# Altium schematic sheet power_do.SchDoc(power_do)
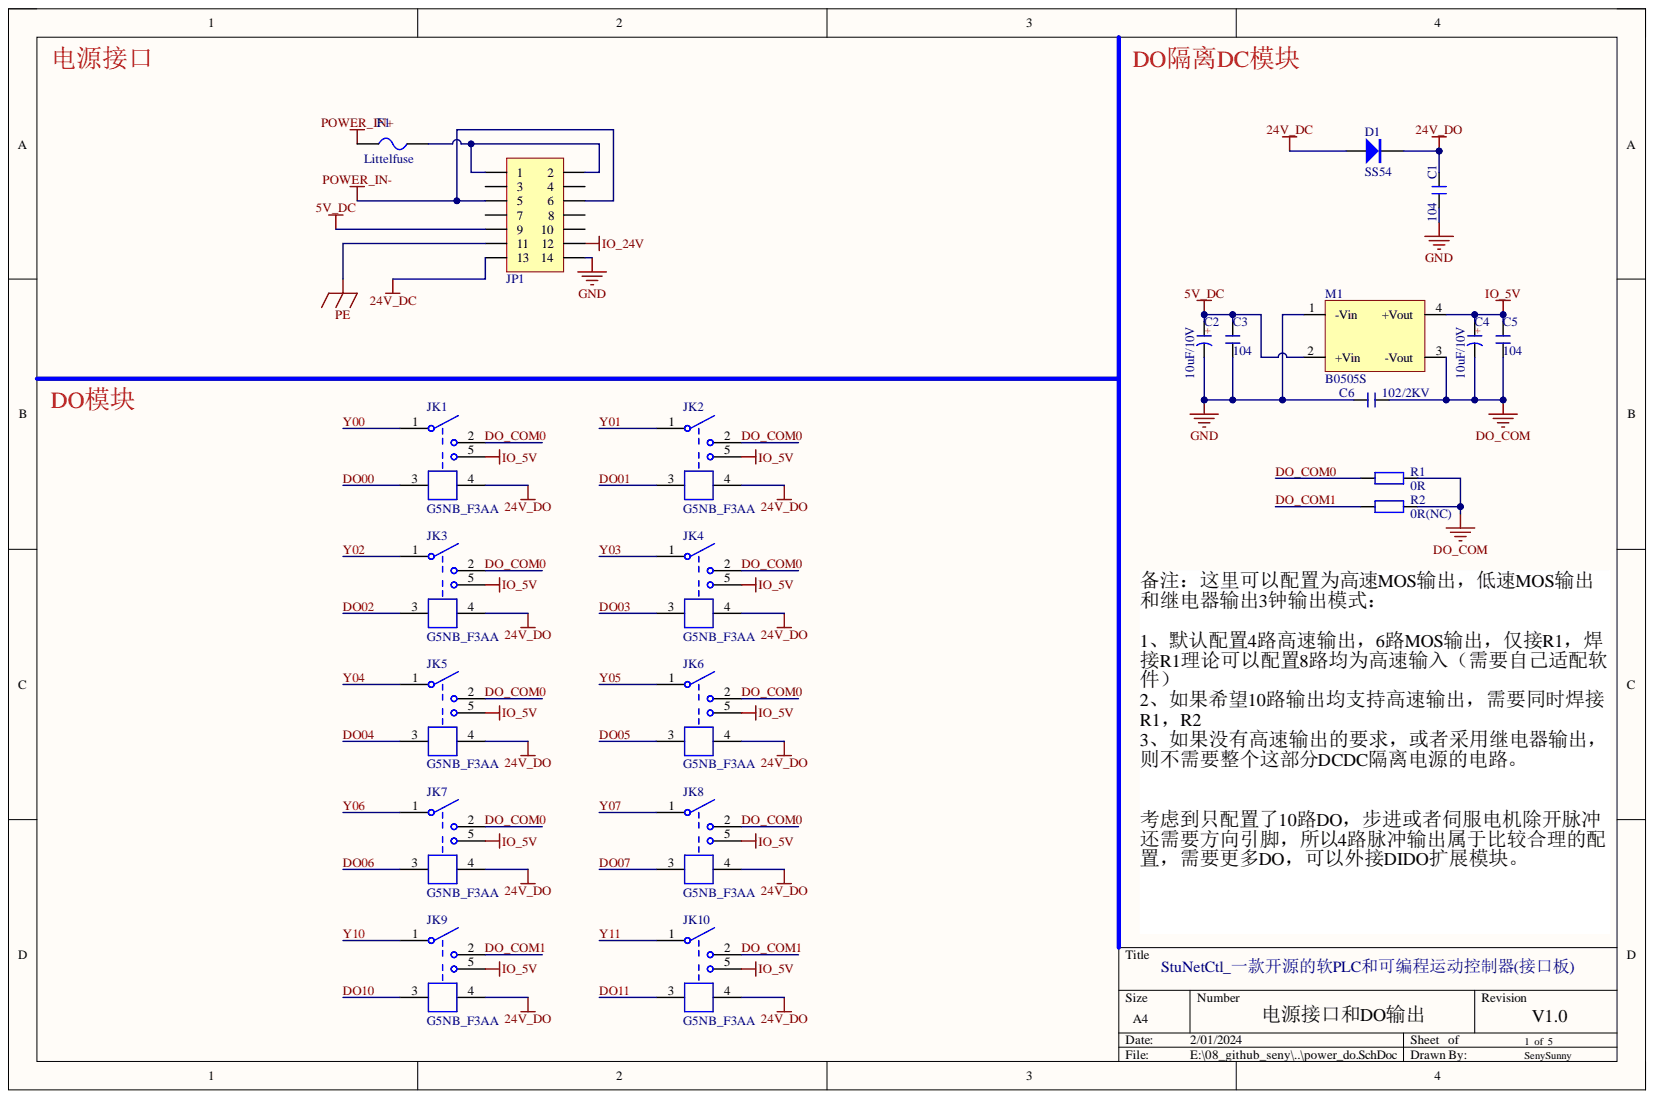
[diagram p0: power_do.SchDoc]

## Parts
C1  104  [C 0603]
C2  10uF/10V  [CD A(3216)]
C3  104  [C 0603]
C4  10uF/10V  [CD A(3216)]
C5  104  [C 0603]
C6  102/2KV  [C 1206]
D1  SS54  [SMA(DO214AC)]
F1  Littelfuse  [FUSE-SMD_L9.8-W5.0]
JK1  G5NB_F3AA  [G5NB_F3AA]
JK2  G5NB_F3AA  [G5NB_F3AA]
JK3  G5NB_F3AA  [G5NB_F3AA]
JK4  G5NB_F3AA  [G5NB_F3AA]
JK5  G5NB_F3AA  [G5NB_F3AA]
JK6  G5NB_F3AA  [G5NB_F3AA]
JK7  G5NB_F3AA  [G5NB_F3AA]
JK8  G5NB_F3AA  [G5NB_F3AA]
JK9  G5NB_F3AA  [G5NB_F3AA]
JK10  G5NB_F3AA  [G5NB_F3AA]
JP1  Header 2X7  [HDR-TH_14P-P2.54-V-R2-C7-S2.54]  (value not drawn)
M1  B0505S  [B0505S-1W]
R1  0R  [R 1206]
R2  0R(NC)  [R 1206]

## Nets
5V_DC: C2.1 C3.2 JP1.9 M1.2(+Vin)
24V_DC: D1.1 JP1.13
24V_DO: C1.1 D1.2 JK1.4 JK2.4 JK3.4 JK4.4 JK5.4 JK6.4 JK7.4 JK8.4 JK9.4 JK10.4
DO_COM: C4.2 C5.1 C6.1 M1.3(-Vout) R1.2 R2.2
GND: C1.2 C2.2 C3.1 C6.2 JP1.14 M1.1(-Vin)
IO_5V: C4.1 C5.2 JK1.5 JK2.5 JK3.5 JK4.5 JK5.5 JK6.5 JK7.5 JK8.5 JK9.5 JK10.5 M1.4(+Vout)
IO_24V: JP1.12
PE: JP1.11
POWER_IN+: F1.1
POWER_IN-: JP1.5 JP1.6
DO00: JK1.3
DO01: JK2.3
DO02: JK3.3
DO03: JK4.3
DO04: JK5.3
DO05: JK6.3
DO06: JK7.3
DO07: JK8.3
DO10: JK9.3
DO11: JK10.3
DO_COM0: JK1.2 JK2.2 JK3.2 JK4.2 JK5.2 JK6.2 JK7.2 JK8.2 R1.1
DO_COM1: JK9.2 JK10.2 R2.1
Y00: JK1.1
Y01: JK2.1
Y02: JK3.1
Y03: JK4.1
Y04: JK5.1
Y05: JK6.1
Y06: JK7.1
Y07: JK8.1
Y10: JK9.1
Y11: JK10.1
NetF1_2: F1.2 JP1.1 JP1.2

Not connected: JP1 3 4 7 8 10

## Library data of the parts
C1 C3 C5 C6 = C: 无极性贴片电容; 版权归属: 源创客; BOM名称: 无极性贴片电容; Component Kind: Standard; 学习资源: www.ooocke.com; Library Name: zblib_v1.IntLib
C2 C4 = C-CD: 贴片钽电容; 版权归属: 源创客; BOM名称: 贴片钽电容; Component Kind: Standard; 学习资源: www.ooocke.com; Library Name: zblib_v1.IntLib
D1 = SS14: 肖特基二极管; 属性: 40V/1A; 版权归属: 源创客; BOM名称: 肖特基二极管; Component Kind: Standard; 学习资源: www.ooocke.com; Library Name: zblib_v1.IntLib
F1 = FU: 贴片保险丝; 版权归属: 源创客; BOM名称: 贴片保险丝; Component Kind: Standard; 学习资源: www.ooocke.com; Library Name: zblib_v1.IntLib
JK1-JK10 = G5NB_F3AA: 单路常开继电器; 版权归属: 源创客; BOM名称: 单路常开继电器; Component Kind: Standard; 学习资源: www.ooocke.com; Library Name: zblib_v1.IntLib
JP1 = Header 7X2: 7*2P接插件; 版权归属: 源创客; BOM名称: 7*2P接插件; Component Kind: Standard; 学习资源: www.ooocke.com; Library Name: zblib_v1.IntLib
M1 = B0505S: DC-DC模块; 版权归属: 源创客; BOM名称: DC-DC模块; Component Kind: Standard; 学习资源: www.ooocke.com; Library Name: zblib_v1.IntLib
R1 R2 = R: 贴片电阻; 版权归属: 源创客; BOM名称: 贴片电阻; Component Kind: Standard; 学习资源: www.ooocke.com; Library Name: zblib_v1.IntLib
